# Altium schematic sheet AIR-SENSOR.SCHDOC
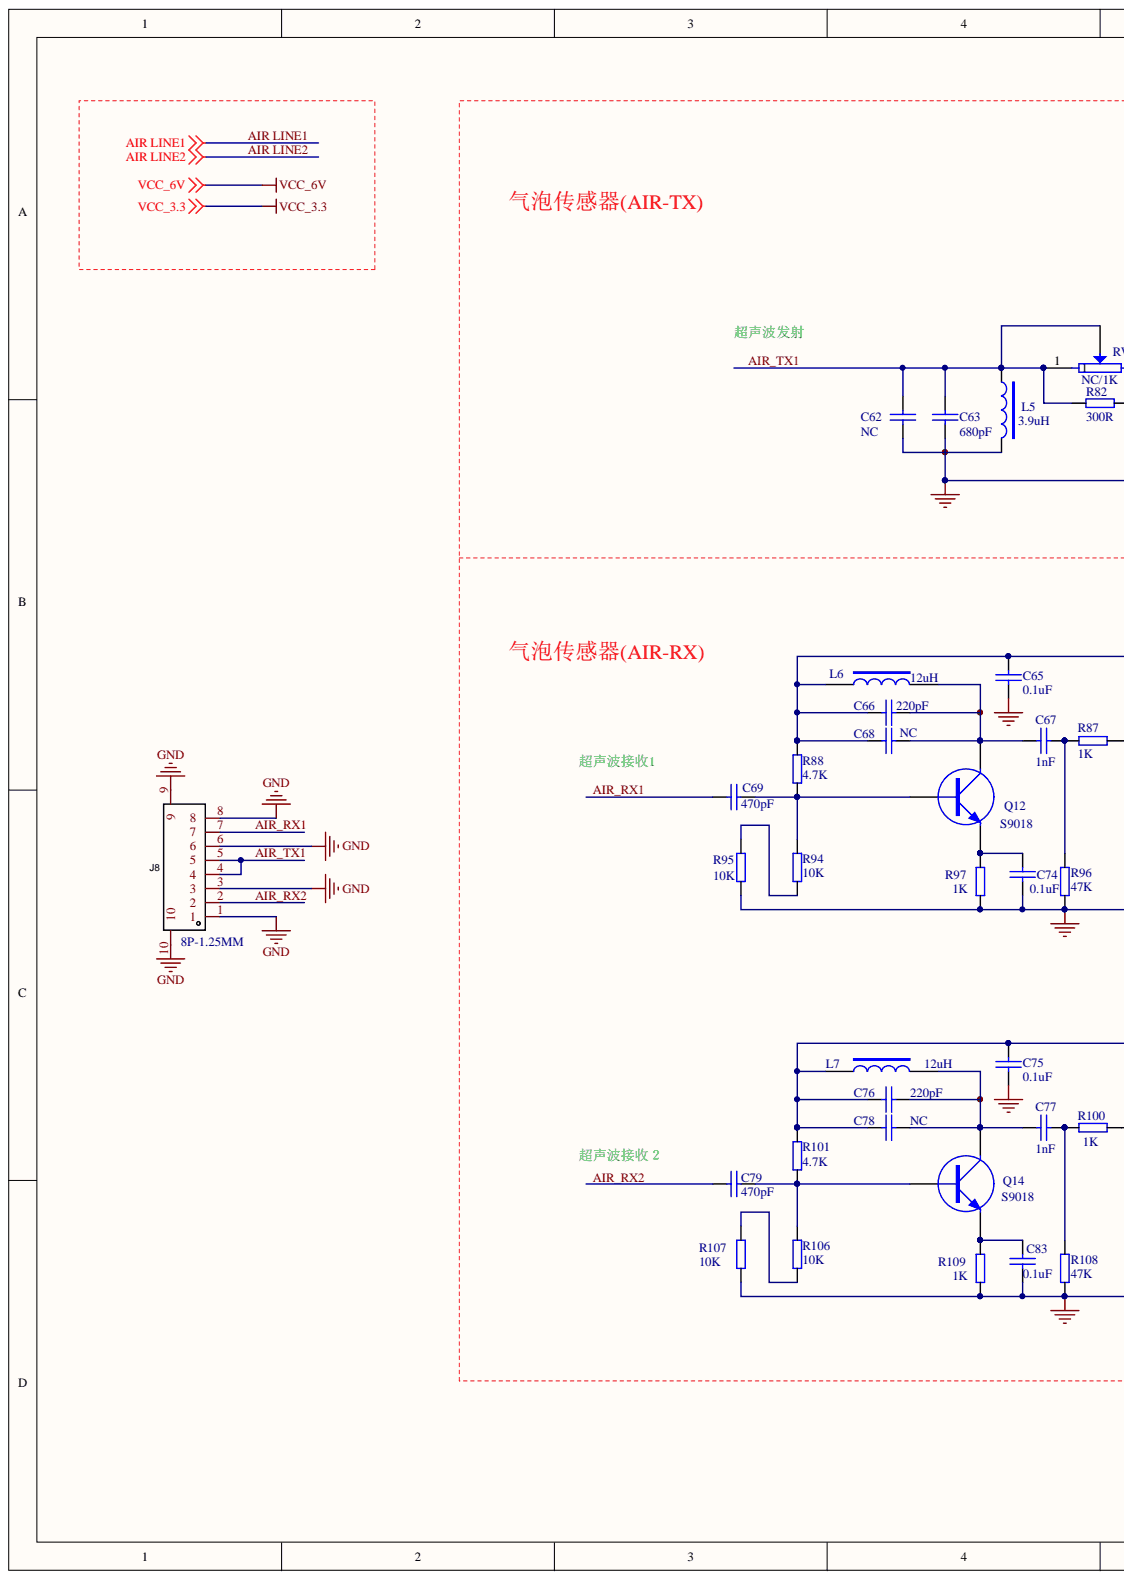
[diagram p0: AIR-SENSOR.SCHDOC, left]
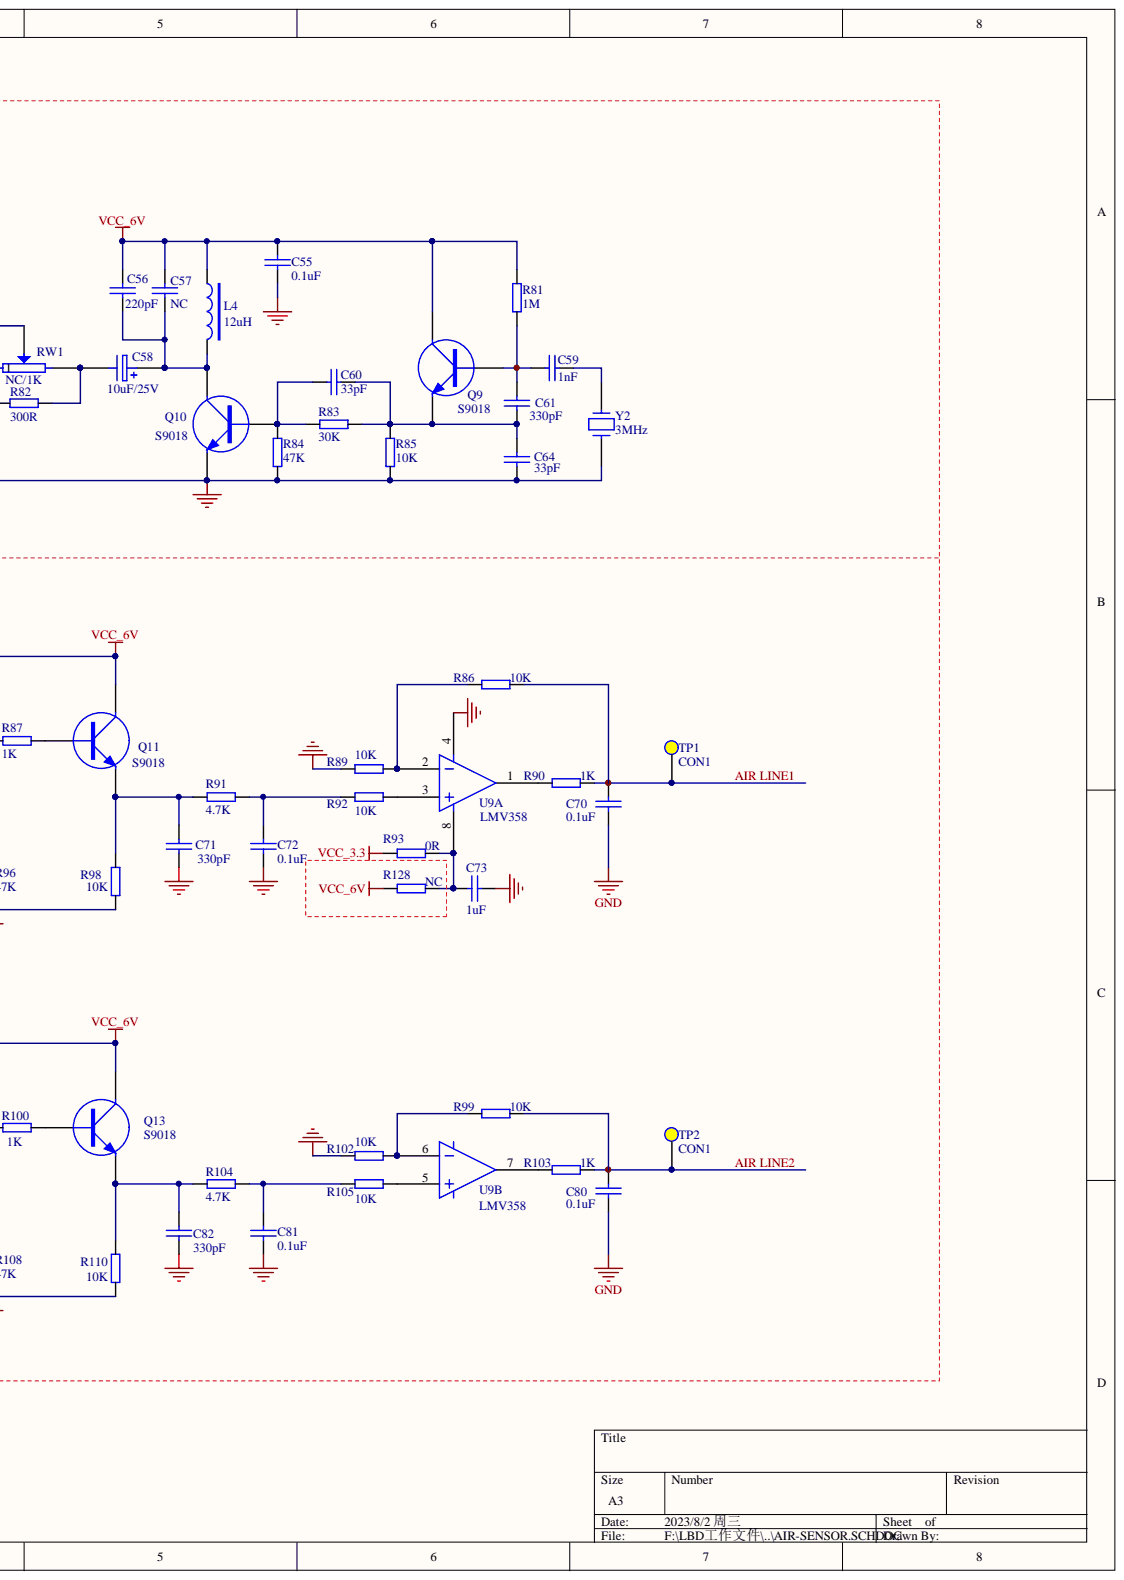
[diagram p1: AIR-SENSOR.SCHDOC, right]

## Parts
C55  0.1uF  [C0402]
C56  220pF  [C0402]
C57  NC  [C0402]
C58  10uF/25V  [CASE-A-1026]
C59  1nF  [C0402]
C60  33pF  [C0402]
C61  330pF  [C0402]
C62  NC  [C0402]
C63  680pF  [C0402]
C64  33pF  [C0402]
C65  0.1uF  [C0402]
C66  220pF  [C0402]
C67  1nF  [C0402]
C68  NC  [C0402]
C69  470pF  [C0402]
C70  0.1uF  [C0402]
C71  330pF  [C0402]
C72  0.1uF  [C0402]
C73  1uF  [C0402]
C74  0.1uF  [C0402]
C75  0.1uF  [C0402]
C76  220pF  [C0402]
C77  1nF  [C0402]
C78  NC  [C0402]
C79  470pF  [C0402]
C80  0.1uF  [C0402]
C81  0.1uF  [C0402]
C82  330pF  [C0402]
C83  0.1uF  [C0402]
J8  8P-1.25MM  [CONN-SMD_8P-P1.25_HDGC_HDGC1251WV-S-8P]
L4  12uH  [R0805]
L5  3.9uH  [R0805]
L6  12uH  [R0805]
L7  12uH  [R0805]
Q9  S9018  [SOT_23]
Q10  S9018  [SOT_23]
Q11  S9018  [SOT_23]
Q12  S9018  [SOT_23]
Q13  S9018  [SOT_23]
Q14  S9018  [SOT_23]
R81  1M  [R0402]
R82  300R  [R0402]
R83  30K  [R0402]
R84  47K  [R0402]
R85  10K  [R0402]
R86  10K  [R0402]
R87  1K  [R0402]
R88  4.7K  [R0402]
R89  10K  [R0402]
R90  1K  [R0402]
R91  4.7K  [R0402]
R92  10K  [R0402]
R93  0R  [R0402]
R94  10K  [R0402]
R95  10K  [R0402]
R96  47K  [R0402]
R97  1K  [R0402]
R98  10K  [R0402]
R99  10K  [R0402]
R100  1K  [R0402]
R101  4.7K  [R0402]
R102  10K  [R0402]
R103  1K  [R0402]
R104  4.7K  [R0402]
R105  10K  [R0402]
R106  10K  [R0402]
R107  10K  [R0402]
R108  47K  [R0402]
R109  1K  [R0402]
R110  10K  [R0402]
R128  NC  [R0402]
RW1  NC/1K  [3323P]
TP1  CON1  [TESTPOINT]
TP2  CON1  [TESTPOINT]
U9  LMV358  [SOP-8]  (parts A,B)
Y2  3MHz  [HC-49UM]

## Nets
GND: C55.2 C62.2 C63.2 C64.2 C65.2 C70.2 C71.2 C72.1 C73.2 C74.2 C75.2 C80.2 C81.1 C82.2 C83.2 J8.1 J8.3 J8.6 J8.8 J8.9 J8.10 L5.2 Q10.2 R84.1 R85.1 R89.2 R95.1 R96.1 R97.1 R98.1 R102.2 R107.1 R108.1 R109.1 R110.1 U9.4 Y2.1
VCC_3.3: R93.2
VCC_6V: C55.1 C56.1 C57.1 C65.1 C66.1 C68.1 C75.1 C76.1 C78.1 L4.1 L6.1 L7.1 Q9.3 Q11.3 Q13.3 R81.2 R88.2 R101.2 R128.2
AIR LINE1: C70.1 R86.1 R90.1 TP1.1
AIR LINE2: C80.1 R99.1 R103.1 TP2.1
AIR_RX1: C69.1 J8.7
AIR_RX2: C79.1 J8.2
AIR_TX1: C62.1 C63.1 J8.4 J8.5 L5.1 R82.2 RW1.1 RW1.2
NetC56_2: C56.2 C57.2 C58.1 L4.2 Q10.3
NetC58_2: C58.2 R82.1 RW1.3
NetC59_1: C59.1 C61.1 Q9.1 R81.1
NetC59_2: C59.2 Y2.2
NetC60_1: C60.1 Q10.1 R83.2 R84.2
NetC60_2: C60.2 C61.2 C64.1 Q9.2 R83.1 R85.2
NetC66_2: C66.2 C67.1 C68.2 L6.2 Q12.3
NetC67_2: C67.2 R87.2 R96.2
NetC69_2: C69.2 Q12.1 R88.1 R94.2
NetC71_1: C71.1 Q11.2 R91.2 R98.2
NetC72_2: C72.2 R91.1 R92.2
NetC73_1: C73.1 R93.1 R128.1 U9.8
NetC74_1: C74.1 Q12.2 R97.2
NetC76_2: C76.2 C77.1 C78.2 L7.2 Q14.3
NetC77_2: C77.2 R100.2 R108.2
NetC79_2: C79.2 Q14.1 R101.1 R106.2
NetC81_2: C81.2 R104.1 R105.2
NetC82_1: C82.1 Q13.2 R104.2 R110.2
NetC83_1: C83.1 Q14.2 R109.2
NetQ11_1: Q11.1 R87.1
NetQ13_1: Q13.1 R100.1
NetR86_2: R86.2 R89.1 U9.2
NetR90_2: R90.2 U9.1
NetR92_1: R92.1 U9.3
NetR94_1: R94.1 R95.2
NetR99_2: R99.2 R102.1 U9.6
NetR103_2: R103.2 U9.7
NetR105_1: R105.1 U9.5
NetR106_1: R106.1 R107.2

## Library data of the parts
C55-C57 C59-C83 = CAP: Capacitor; Component Kind: Standard
C58 = ELECTRO1: Electrolytic Capacitor; Component Kind: Standard
J8 = HDGC1251WV-S-8P: Add into BOM: yes; Component Kind: Standard; Convert to PCB: yes; Datasheet: https://atta.szlcsc.com/upload/public/pdf/source/20211224/CF52F4619478E34B777B51F51475CF6A.pdf; Device: HDGC1251WV-S-8P; JLCPCB Part Class: Extended Part; Manufacturer Part: HDGC1251WV-S-8P; Name: HDGC1251WV-S-8P; PCB3D: CONN-SMD_8P-P1.25_HDGC_HDGC1251WV-S-8P; Supplier: LCSC; Supplier Footprint: SMD,P=1.25mm; Supplier Part: C2936212; Symbol: HDGC1251WV-S-8P
L4-L7 = INDUCTOR2: Component Kind: Standard
Q9-Q14 = NPN: NPN Transistor; Component Kind: Standard
R81-R110 R128 = RES1: Component Kind: Standard; Library Name: Integrated_Library.IntLib
RW1 = POT2: Potentiometer; Component Kind: Standard
TP1 TP2 = TESTPOINT: Component Kind: Standard; Library Name: Integrated_Library.IntLib
U9 = LF353: Component Kind: Standard
Y2 = CRYSTAL: Component Kind: Standard; Description: Crystal
